# Altium schematic sheet Motor Driver Driver.SchDoc
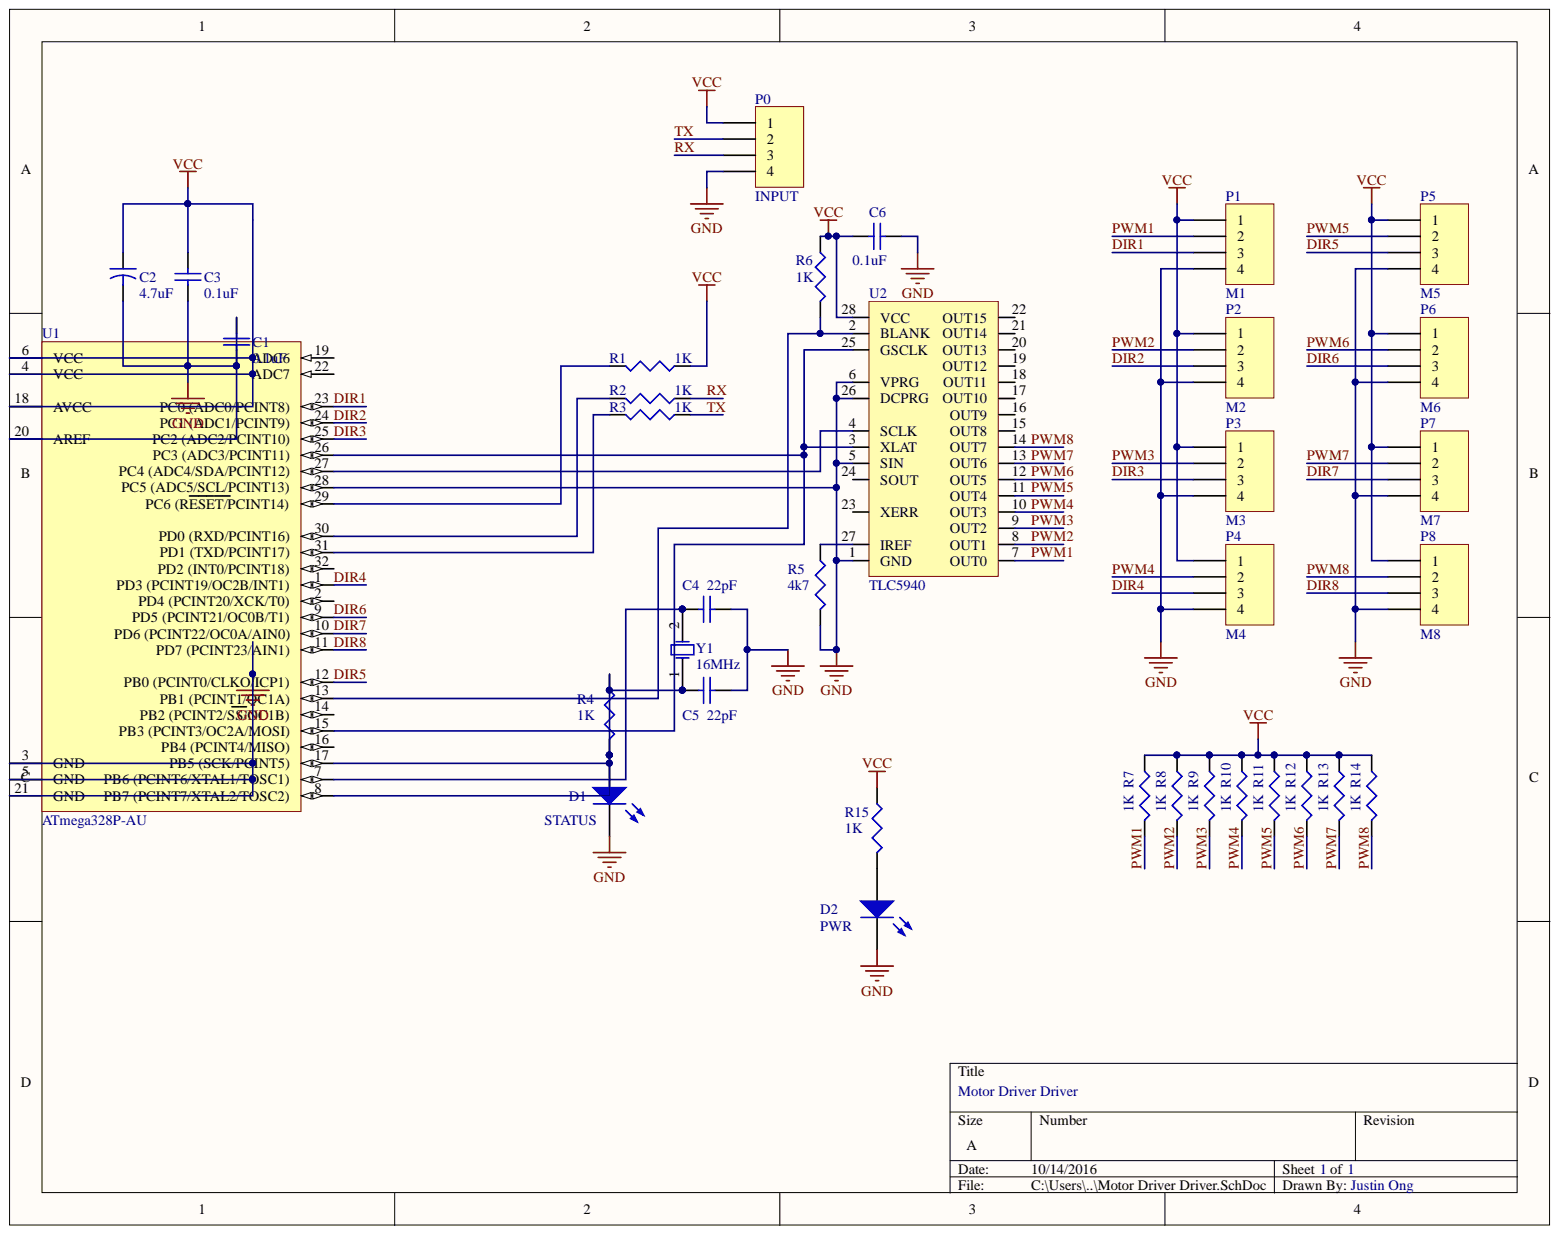
[diagram p0: Motor Driver Driver.SchDoc]

## Parts
C1  value 0.1uF  [C0805]  (hidden comment: Cap)
C2  value 4.7uF  [C0805]  (hidden comment: Cap2)
C3  value 0.1uF  [C0805]  (hidden comment: Cap)
C4  value 22pF  [C0805]  (hidden comment: Cap)
C5  value 22pF  [C0805]  (hidden comment: Cap)
C6  value 0.1uF  [C0805]  (hidden comment: Cap)
D1  STATUS  [3.2X1.6X1.1]
D2  PWR  [3.2X1.6X1.1]
P0  INPUT  [HDR1X4]
P1  M1  [HDR1X4]
P2  M2  [HDR1X4]
P3  M3  [HDR1X4]
P4  M4  [HDR1X4]
P5  M5  [HDR1X4]
P6  M6  [HDR1X4]
P7  M7  [HDR1X4]
P8  M8  [HDR1X4]
R1  value 1K  [J1-0603]  (hidden comment: Res3)
R2  value 1K  [J1-0603]  (hidden comment: Res3)
R3  value 1K  [J1-0603]  (hidden comment: Res3)
R4  value 1K  [J1-0603]  (hidden comment: Res3)
R5  value 4k7  [J1-0603]  (hidden comment: Res3)
R6  value 1K  [J1-0603]  (hidden comment: Res3)
R7  value 1K  [J1-0603]  (hidden comment: Res3)
R8  value 1K  [J1-0603]  (hidden comment: Res3)
R9  value 1K  [J1-0603]  (hidden comment: Res3)
R10  value 1K  [J1-0603]  (hidden comment: Res3)
R11  value 1K  [J1-0603]  (hidden comment: Res3)
R12  value 1K  [J1-0603]  (hidden comment: Res3)
R13  value 1K  [J1-0603]  (hidden comment: Res3)
R14  value 1K  [J1-0603]  (hidden comment: Res3)
R15  value 1K  [J1-0603]  (hidden comment: Res3)
U1  ATmega328P-AU  [32A_N]
U2  TLC5940  [PWP HTSSOP 28]
Y1  16MHz  [RAD-0.2]

## Nets
GND: C1.1 C1.2 C2.2 C3.1 C4.1 C5.1 C6.2 D1.2 D2.2 P0.4 P1.4 P2.4 P3.4 P4.4 P5.4 P6.4 P7.4 P8.4 R5.2 U1.3(GND) U1.5(GND) U1.20(AREF) U1.21(GND) U1.28(PC5_(ADC5/SCL/PCINT13)) U2.1(GND) U2.5(SIN) U2.6(VPRG) U2.26(DCPRG)
VCC: C2.1 C3.2 C6.1 P0.1 P1.1 P2.1 P3.1 P4.1 P5.1 P6.1 P7.1 P8.1 R1.1 R6.1 R7.1 R8.1 R9.1 R10.1 R11.1 R12.1 R13.1 R14.1 R15.1 U1.4(VCC) U1.6(VCC) U1.18(AVCC) U2.28(VCC)
DIR1: P1.3 U1.23(PC0_(ADC0/PCINT8))
DIR2: P2.3 U1.24((ADC1/PCINT9))
DIR3: P3.3 U1.25
DIR4: P4.3 U1.1(PD3_(PCINT19/OC2B/INT1))
DIR5: P5.3 U1.12(PB0_(PCINT0/CLKO/ICP1))
DIR6: P6.3 U1.9(PD5_(PCINT21/OC0B/T1))
DIR7: P7.3 U1.10(PD6_(PCINT22/OC0A/AIN0))
DIR8: P8.3 U1.11(PD7_(PCINT23/AIN1))
PWM1: P1.2 R7.2 U2.7(OUT0)
PWM2: P2.2 R8.2 U2.8(OUT1)
PWM3: P3.2 R9.2 U2.9(OUT2)
PWM4: P4.2 R10.2 U2.10(OUT3)
PWM5: P5.2 R11.2 U2.11(OUT4)
PWM6: P6.2 R12.2 U2.12(OUT5)
PWM7: P7.2 R13.2 U2.13(OUT6)
PWM8: P8.2 R14.2 U2.14(OUT7)
RX: P0.3 R2.1
TX: P0.2 R3.1
NetC4_2: C4.2 U1.7 Y1.2
NetC5_2: C5.2 D1.1 R4.1 R4.2 U1.8 U1.17(PB5_(SCK/PCINT5)) Y1.1
NetD2_1: D2.1 R15.2
NetR1_2: R1.2 U1.29(PC6_(RESET/PCINT14))
NetR2_2: R2.2 U1.30(PD0_(RXD/PCINT16))
NetR3_2: R3.2 U1.31(PD1_(TXD/PCINT17))
NetR5_1: R5.1 U2.27(IREF)
NetR6_2: R6.2 U1.13(PB1_(PCINT1/OC1A)) U2.2(BLANK)
NetU1_15: U1.15(PB3_(PCINT3/OC2A/MOSI)) U1.26(PC3_(ADC3/PCINT11)) U2.3(XLAT) U2.25(GSCLK)
NetU1_27: U1.27(PC4_(ADC4/SDA/PCINT12)) U2.4(SCLK)

Not connected: U1 2(PD4_(PCINT20/XCK/T0)) 14((PCINT2/SS/OC1B)) 16(PB4_(PCINT4/MISO)) 19(ADC6) 22(ADC7) 32(PD2_(INT0/PCINT18)); U2 15(OUT8) 16(OUT9) 17(OUT10) 18(OUT11) 19(OUT12) 20(OUT13) 21(OUT14) 22(OUT15) 23(XERR) 24(SOUT)

## Library data of the parts
C1 C3-C6 = Cap: Capacitor; Component Kind: Standard; LatestRevisionDate: 17-Jul-2002; LatestRevisionNote: Re-released for DXP Platform.; Library Name: Miscellaneous Devices.IntLib; PackageDescription: Radial Cap, Thru-Hole; 2 Leads; 0.3 in Pin Spacing; PackageReference: RAD-0.3; Published: 8-Jun-2000; Signal Integrity: Cap; Simulation: CAP; Publisher: Altium Limited
  C1 C3 C6: RS: http://sg.rs-online.com/web/p/ceramic-multilayer-capacitors/6480957/
  C4 C5: RS: http://sg.rs-online.com/web/p/ceramic-multilayer-capacitors/0148222/
C2 = Cap2: Capacitor; Component Kind: Standard; Library Name: Miscellaneous Devices.IntLib; PackageDescription: Capacitor; 2 Leads; PackageReference: CAPR5-4X5; Published: 23-Sep-2002; RS: http://sg.rs-online.com/web/p/ceramic-multilayer-capacitors/6911186/; Signal Integrity: Cap; Simulation: CAP; Publisher: Altium Limited
D1 D2 = LED2: Typical RED, GREEN, YELLOW, AMBER GaAs LED; Component Kind: Standard; LatestRevisionDate: 17-Jul-2002; LatestRevisionNote: Re-released for DXP Platform.; Library Name: Miscellaneous Devices.IntLib; PackageDescription: SM LED; 2 Flat Leads; PackageReference: 3.2X1.6X1.1; PackageVersion: Feb-2002; Published: 8-Jun-2000; Simulation: LED2; Publisher: Altium Limited
P0-P8 = Header 4: Header, 4-Pin; Component Kind: Standard; LatestRevisionDate: 17-Jul-2002; LatestRevisionNote: Re-released for DXP Platform.; Library Name: Miscellaneous Connectors.IntLib; Signal Integrity: Connector; Publisher: Altium Limited
R1-R15 = Res3: Resistor; Component Kind: Standard; LatestRevisionDate: 29-May-2009; LatestRevisionNote: IPC-7351 Footprint Added.; Library Name: Miscellaneous Devices.IntLib; PackageDescription: Chip Resistor; PackageReference: J1-0603; Published: 8-Jun-2000; Signal Integrity: Res; Simulation: RESISTOR; Publisher: Altium Limited
U1 = ATmega328P-AU: 8-bit AVR Microcontroller, 32KB Flash, 1KB EEPROM, 2KB SRAM, 32-pin TQFP, Industrial Grade (-40°C to 85°C); Code_JEDEC: MS-026-ABA; Component Kind: Standard; ComponentLink1Description: Manufacturer Link; ComponentLink1URL: http://www.atmel.com/products/avr/default.asp?; ComponentLink2Description: ATmega48A/48PA/88A/88PA/168A/168PA/328/328P Datasheet; ComponentLink2URL: http://www.atmel.com/dyn/resources/prod_documents/8271.pdf; ComponentLink3Description: ATmega48A/48PA/88A/88PA/168A/168PA/328/328P Summary; ComponentLink3URL: http://www.atmel.com/dyn/resources/prod_documents/8271S.pdf; ComponentLink4Description: Atmel.com Home; ComponentLink4URL: http://www.atmel.com; DatasheetVersion: revA, Jan-2010; Library Name: Atmel Microcontroller 8-Bit megaAVR.IntLib; PackageDescription: 32-Lead, 7x7x1.0 mm Body, 0.8mm Lead Pitch, Thin Profile Plastic Quad Flat Package (TQFP); PackageReference: 32A; PackageVersion: revB, Oct-2001; Published: 1-Apr-2010; Supplier 1: Digi-Key; Supplier 2: Farnell; Supplier 3: Mouser; Supplier Part Number 1: ATMEGA328P-AU-ND; Supplier Part Number 2: 1715486; Supplier Part Number 3: 556-ATMEGA328P-AU; Publisher: Altium Limited
Y1 = XTAL: Crystal Oscillator; Component Kind: Standard; LatestRevisionDate: 17-Jul-2002; LatestRevisionNote: Re-released for DXP Platform.; Library Name: Miscellaneous Devices.IntLib; PackageDescription: Crystal, Thru-Hole; 2 Leads; Body 3.1 x 8.2 mm (Dia.xH); PackageReference: R38; Published: 8-Jun-2000; Simulation: XTAL; Publisher: Altium Limited
U2 = TLC5940: Component Kind: Standard; Library Name: TLC5940.SchLib; RS: http://sg.rs-online.com/web/p/led-display-drivers/0389344/
